# Altium schematic sheet PreAmp.SchDoc
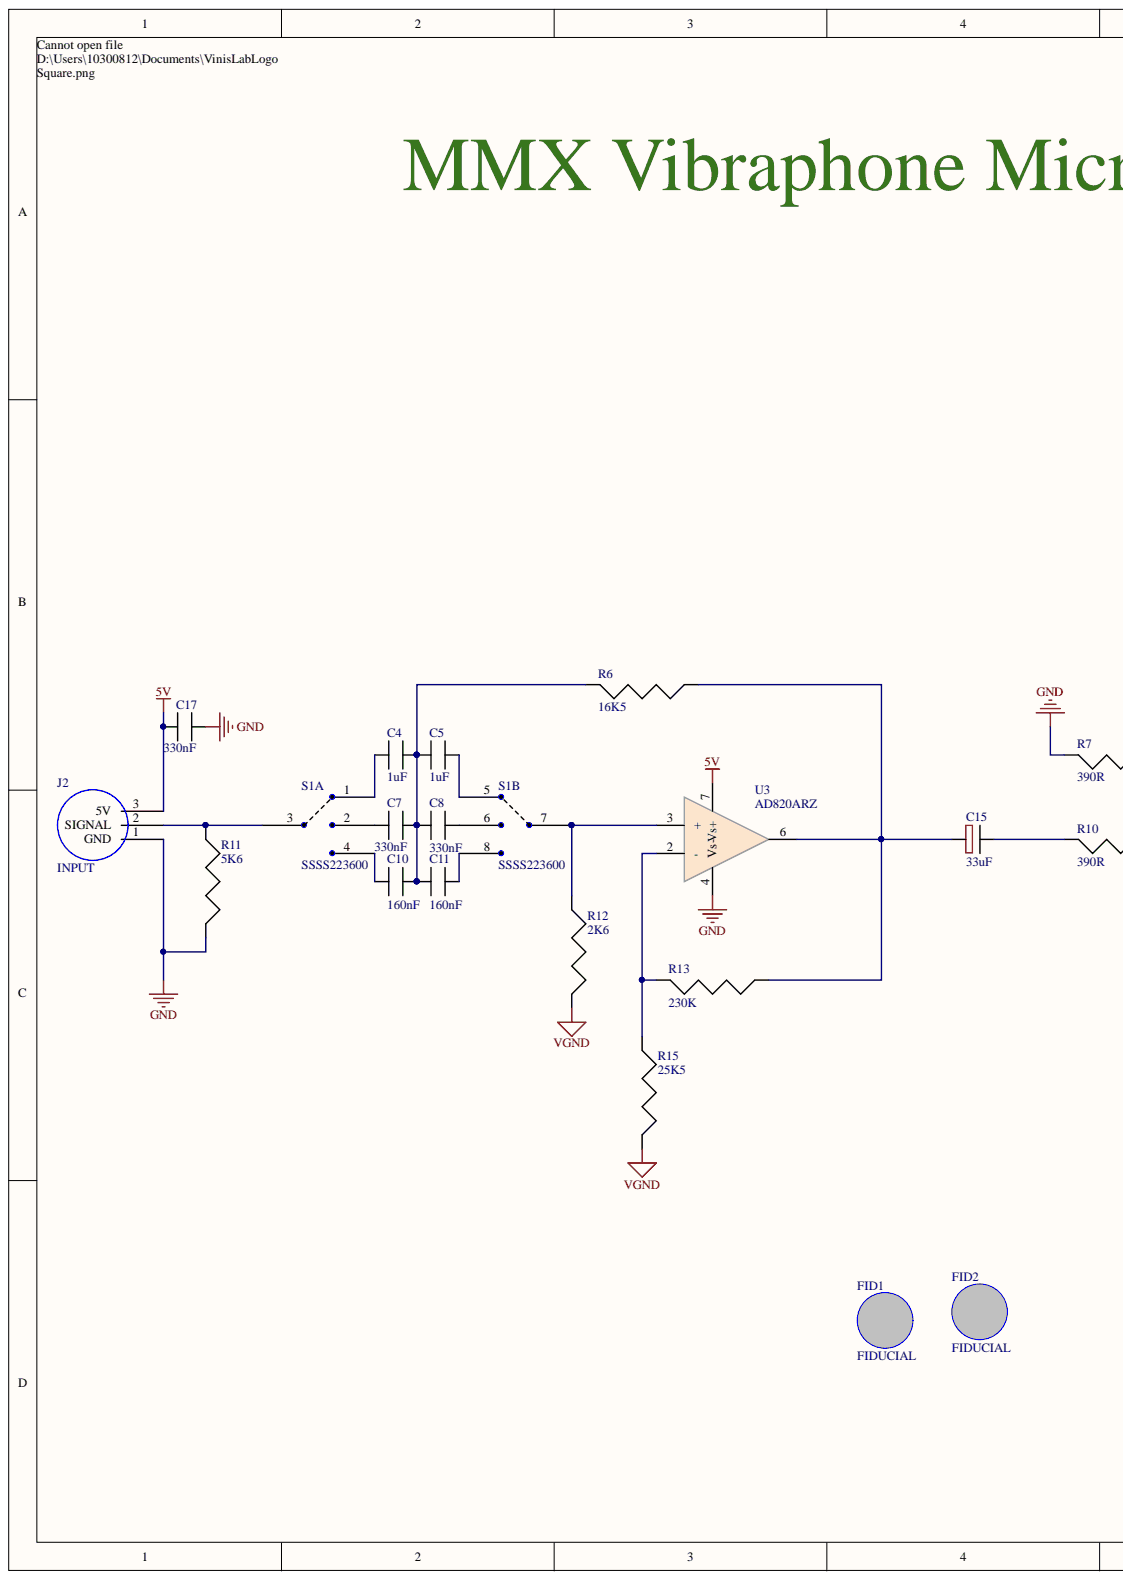
[diagram p0: PreAmp.SchDoc, left]
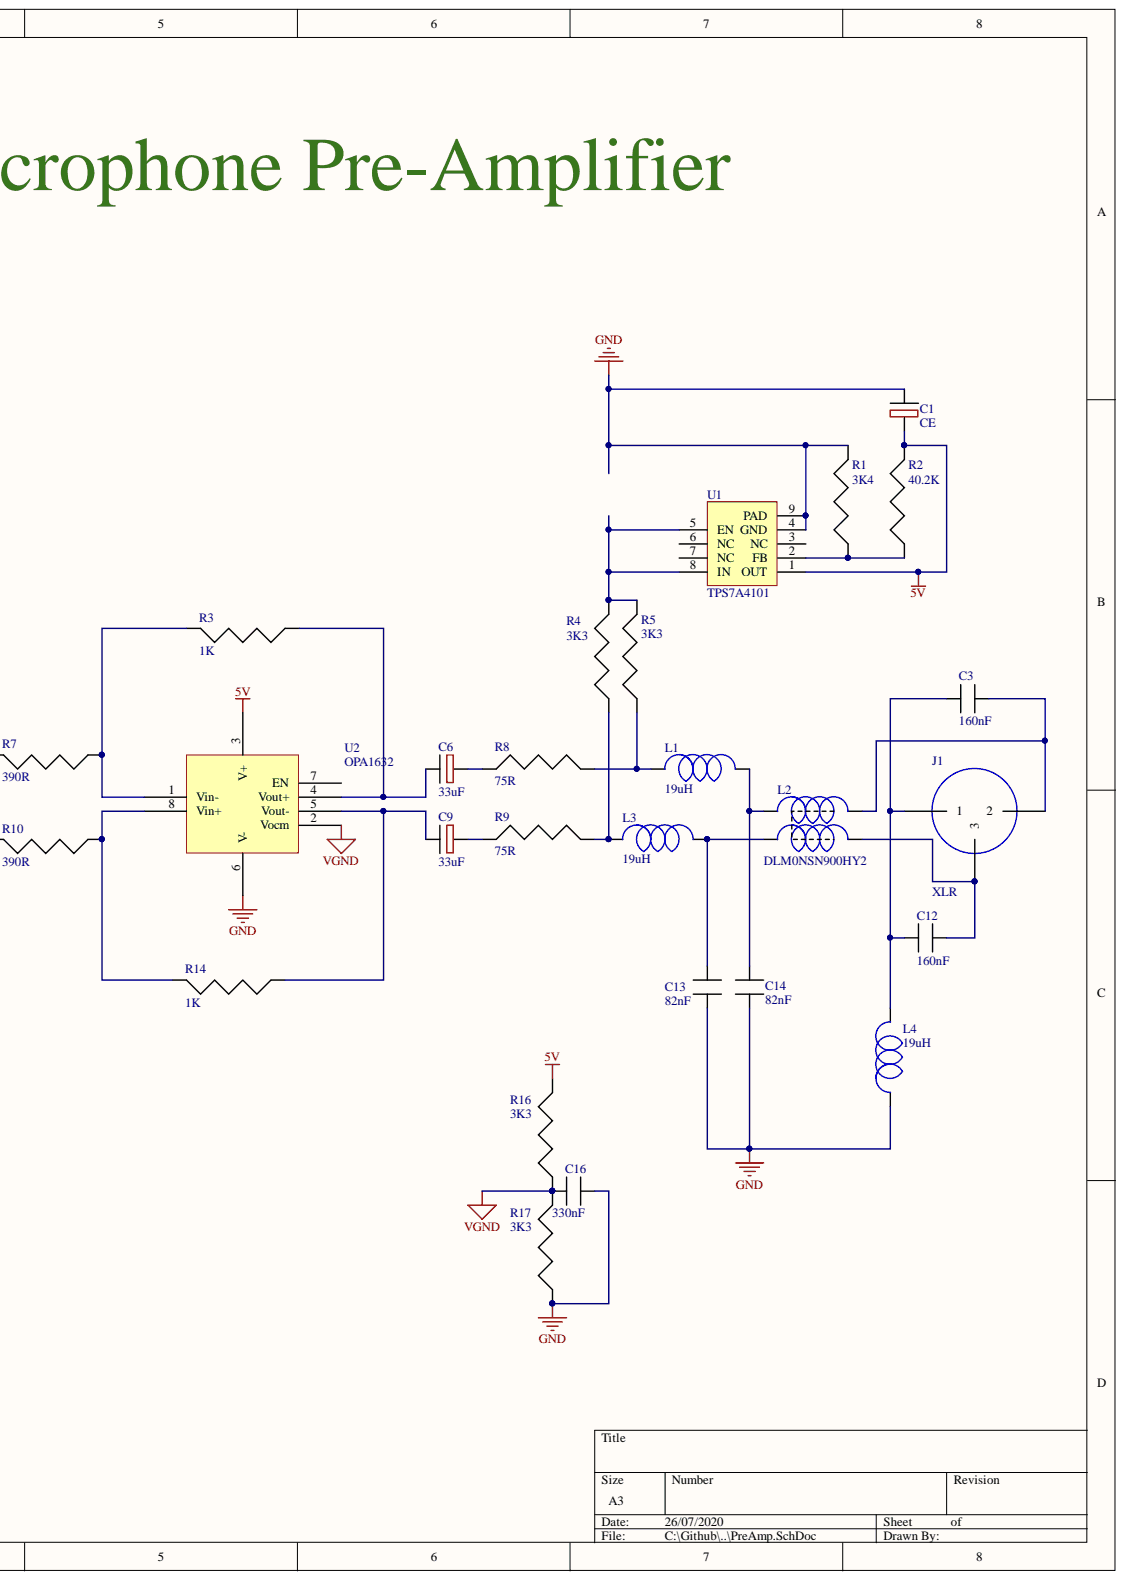
[diagram p1: PreAmp.SchDoc, right]

## Parts
C1  CE  [UFW1J330MED]
C3  160nF  [C2220]
C4  1uF  [C1210]
C5  1uF  [C1210]
C6  33uF  [UFW1J330MED]
C7  330nF  [C1206]
C8  330nF  [C1206]
C9  33uF  [UFW1J330MED]
C10  160nF  [C2220]
C11  160nF  [C2220]
C12  160nF  [C2220]
C13  82nF  [C0805]
C14  82nF  [C0805]
C15  33uF  [UFW1J330MED]
C16  330nF  [C1206]
C17  330nF  [C1206]
FID1  FIDUCIAL  [FIDUCIAL]
FID2  FIDUCIAL  [FIDUCIAL]
J1  XLR  [XLR]
J2  INPUT  [INPUT]
L1  19uH  [C0805]
L2  DLM0NSN900HY2  [DLM0NSN900HY2]
L3  19uH  [C0805]
L4  19uH  [C0805]
R1  3K4  [MMA0204]
R2  40.2K  [MMA0204]
R3  1K  [MMA0204]
R4  3K3  [MMA0204]
R5  3K3  [MMA0204]
R6  16K5  [MMA0204]
R7  390R  [MMA0204]
R8  75R  [MMA0204]
R9  75R  [MMA0204]
R10  390R  [MMA0204]
R11  5K6  [MMA0204]
R12  2K6  [MMA0204]
R13  230K  [MMA0204]
R14  1K  [MMA0204]
R15  25K5  [MMA0204]
R16  3K3  [MMA0204]
R17  3K3  [MMA0204]
S1  SSSS223600  [SSSS223600]  (parts A,B)
U1  TPS7A4101  [HVSSOP-8]
U2  OPA1632  [SOIC-8]
U3  AD820ARZ  [SOIC-8]

## Nets
5V: C1.1 C17.1 J2.3 R2.2 R16.2 U1.1(OUT) U2.3(V+) U3.7(Vs+)
GND: C1.2 C13.2 C14.2 C16.2 C17.2 J2.1 L4.1 R1.2 R7.2 R11.2 R17.1 U1.4(GND) U1.9(PAD) U2.6(V-) U3.4(Vs-)
VGND: C16.1 R12.2 R15.2 R16.1 R17.2 U2.2(Vocm)
NetC3_1: C3.1 C12.1 J1.1 L4.2
NetC3_2: C3.2 J1.2 L2.2
NetC4_1: C4.1 S1.1
NetC4_2: C4.2 C5.1 C7.2 C8.1 C10.2 C11.1 R6.2
NetC5_2: C5.2 S1.5
NetC6_1: C6.1 R8.1
NetC6_2: C6.2 R3.1 U2.4(Vout+)
NetC7_1: C7.1 S1.2
NetC8_2: C8.2 S1.6
NetC9_1: C9.1 R9.1
NetC9_2: C9.2 R14.1 U2.5(Vout-)
NetC10_1: C10.1 S1.4
NetC11_2: C11.2 S1.8
NetC12_2: C12.2 J1.3 L2.3
NetC13_1: C13.1 L2.4 L3.2
NetC14_1: C14.1 L1.2 L2.1
NetC15_1: C15.1 R6.1 R13.1 U3.6
NetC15_2: C15.2 R10.2
NetJ2_2: J2.2 R11.1 S1.3
NetL1_1: L1.1 R5.1 R8.2
NetL3_1: L3.1 R4.1 R9.2
NetR1_1: R1.1 R2.1 U1.2(FB)
NetR3_2: R3.2 R7.1 U2.1(Vin-)
NetR4_2: R4.2 R5.2 U1.5(EN) U1.8(IN)
NetR10_1: R10.1 R14.2 U2.8(Vin+)
NetR12_1: R12.1 S1.7 U3.3(+)
NetR13_2: R13.2 R15.1 U3.2(-)

Not connected: U1 3(NC) 6(NC) 7(NC); U2 7(EN)

## Library data of the parts
C3-C5 C7 C8 C10-C14 C16 C17 = C: Component Kind: Standard; Library Name: MicLib.SchLib
C6 C9 C15 = CE: Component Kind: Standard; Library Name: MicLib.SchLib
L1 L3 L4 = Inductor: Component Kind: Standard; Inductance: 19uH; Library Name: MicLib.SchLib
L2 = DLM0NSN900HY2: Resistance: R; Component Kind: Standard; Library Name: MicLib.SchLib
R1 R2 R4 R5 R8 R9 R11 R16 R17 = Resistor: Component Kind: Standard; Library Name: MicLib.SchLib
  R1: Resistance: 3K4
  R2: Resistance: 40.2K
  R4 R5 R16 R17: Resistance: 3K3
  R8 R9: Resistance: 75R
  R11: Resistance: 5K6
R3 R6 R7 R10 R12-R15 = Resistor: Resistance: 3K3; Component Kind: Standard; Library Name: MicLib.SchLib
C1 = CE: Component Kind: Standard; Library Name: MicLib.SchLib
FID1 FID2 = FIDUCIAL: Component Kind: Standard; Library Name: MicLib.SchLib
J1 = XLR: Component Kind: Standard; Library Name: MicLib.SchLib
J2 = INPUT: Component Kind: Standard; Library Name: MicLib.SchLib
S1 = SSSS223600: Component Kind: Standard; Library Name: MicLib.SchLib
U1 = TPS7A4101: Component Kind: Standard; Library Name: MicLib.SchLib
U2 = OPA1632: Component Kind: Standard; Library Name: MicLib.SchLib
U3 = AD820ARZ: Component Kind: Standard; Library Name: MicLib.SchLib
